# Altium schematic sheet DPad.SchDoc(DPad)
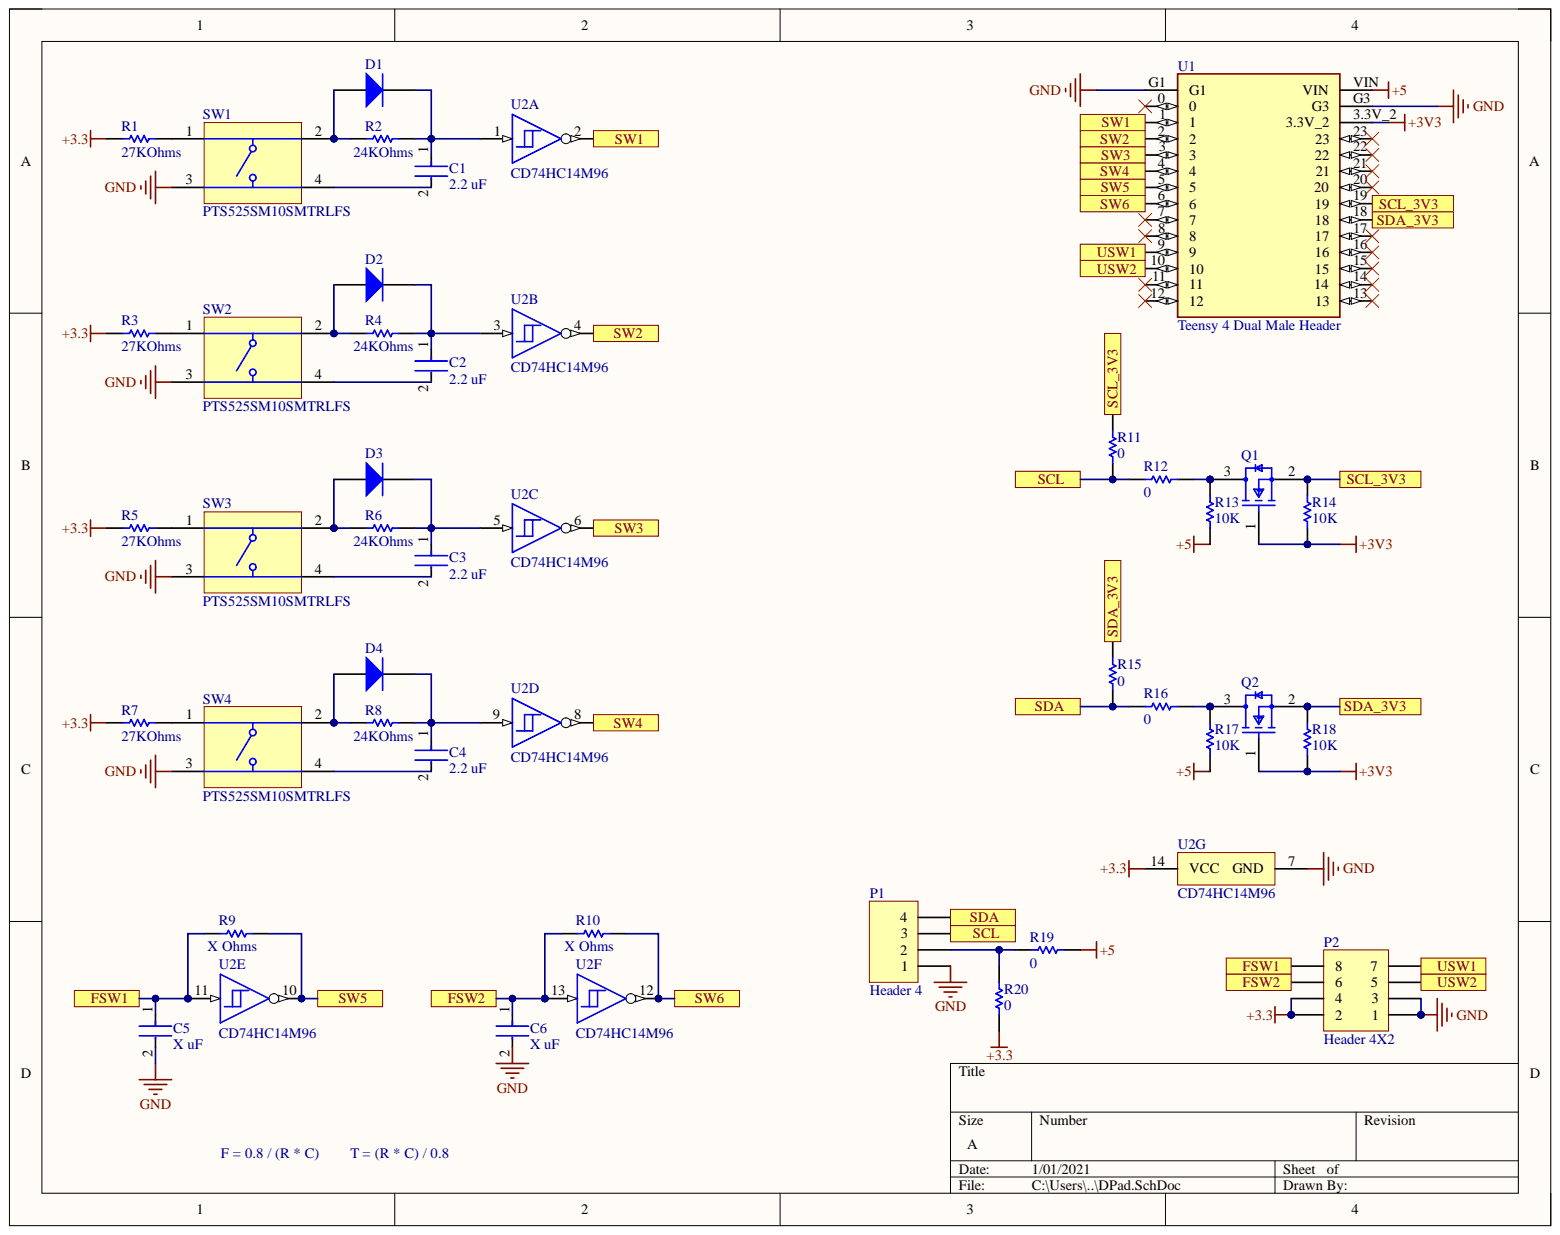
[diagram p0: DPad.SchDoc]

## Parts
C1  value 2.2 uF  Capacitance: 1 uF  [FP-CC0805-0_85-IPC_B]  (hidden comment: CC0805KKX7R6BB105)
C2  value 2.2 uF  Capacitance: 1 uF  [FP-CC0805-0_85-IPC_B]  (hidden comment: CC0805KKX7R6BB105)
C3  value 2.2 uF  Capacitance: 1 uF  [FP-CC0805-0_85-IPC_B]  (hidden comment: CC0805KKX7R6BB105)
C4  value 2.2 uF  Capacitance: 1 uF  [FP-CC0805-0_85-IPC_B]  (hidden comment: CC0805KKX7R6BB105)
C5  value X uF  Capacitance: 1 uF  [FP-CC0805-0_85-IPC_B]  (hidden comment: CC0805KKX7R6BB105)
C6  value X uF  Capacitance: 1 uF  [FP-CC0805-0_85-IPC_B]  (hidden comment: CC0805KKX7R6BB105)
D1  1N4148WS-E3-08  [VISH-SOD-323-2_V]  (value not drawn)
D2  1N4148WS-E3-08  [VISH-SOD-323-2_V]  (value not drawn)
D3  1N4148WS-E3-08  [VISH-SOD-323-2_V]  (value not drawn)
D4  1N4148WS-E3-08  [VISH-SOD-323-2_V]  (value not drawn)
P1  Header 4  [HDR1X4]
P2  Header 4X2  [HDR2X4]
Q1  BSS138  [FP-318-08-IPC_B]  (value not drawn)
Q2  BSS138  [FP-318-08-IPC_B]  (value not drawn)
R1  value 27KOhms  [RESC2013X50X30LL20T20]  (hidden comment: CRCW08050000Z0EA)
R2  value 24KOhms  [RESC2013X50X30LL20T20]  (hidden comment: CRCW08050000Z0EA)
R3  value 27KOhms  [RESC2013X50X30LL20T20]  (hidden comment: CRCW08050000Z0EA)
R4  value 24KOhms  [RESC2013X50X30LL20T20]  (hidden comment: CRCW08050000Z0EA)
R5  value 27KOhms  [RESC2013X50X30LL20T20]  (hidden comment: CRCW08050000Z0EA)
R6  value 24KOhms  [RESC2013X50X30LL20T20]  (hidden comment: CRCW08050000Z0EA)
R7  value 27KOhms  [RESC2013X50X30LL20T20]  (hidden comment: CRCW08050000Z0EA)
R8  value 24KOhms  [RESC2013X50X30LL20T20]  (hidden comment: CRCW08050000Z0EA)
R9  value X Ohms  [RESC2013X50X30LL20T20]  (hidden comment: CRCW08050000Z0EA)
R10  value X Ohms  [RESC2013X50X30LL20T20]  (hidden comment: CRCW08050000Z0EA)
R11  0  [RESC2013X50X30LL20T20]
R12  0  [RESC2013X50X30LL20T20]
R13  10K  [RESC2013X50X30LL20T20]
R14  10K  [RESC2013X50X30LL20T20]
R15  0  [RESC2013X50X30LL20T20]
R16  0  [RESC2013X50X30LL20T20]
R17  10K  [RESC2013X50X30LL20T20]
R18  10K  [RESC2013X50X30LL20T20]
R19  0  [RESC2013X50X30LL20T20]
R20  0  [RESC2013X50X30LL20T20]
SW1  PTS525SM10SMTRLFS  [CKCP-PTS525SM10SMTR-4_V]
SW2  PTS525SM10SMTRLFS  [CKCP-PTS525SM10SMTR-4_V]
SW3  PTS525SM10SMTRLFS  [CKCP-PTS525SM10SMTR-4_V]
SW4  PTS525SM10SMTRLFS  [CKCP-PTS525SM10SMTR-4_V]
U1  Teensy 4 Dual Male Header  [Teensy 4 Dual Male Header-Footprint-2]
U2  CD74HC14M96  [D0014A_M]  (parts A,B,C,D,E,F,G)

## Ports
FSW1, FSW2, SCL, SCL_3V3, SDA, SDA_3V3, SW1, SW2, SW3, SW4, SW5, SW6, USW1, USW2

## Nets
+3.3: P2.2 P2.4 R1.2 R3.2 R5.2 R7.2 R20.2 U2.14(VCC)
+3V3: Q1.1 Q2.1 R14.1 R18.1 U1.3.3V_2
+5: R13.1 R17.1 R19.1 U1.VIN
GND: C5.2 C6.2 P1.1 P2.1 P2.3 SW1.3 SW2.3 SW3.3 SW4.3 U1.G1 U1.G3 U2.7(GND)
FSW1: C5.1 P2.8 R9.1 U2.11 ; port FSW1
FSW2: C6.1 P2.6 R10.1 U2.13 ; port FSW2
SCL: P1.3 R11.1 R12.2 ; port SCL
SCL_3V3: Q1.2 R11.2 R14.2 U1.19 ; port SCL_3V3
SDA: P1.4 R15.2 R16.2 ; port SDA
SDA_3V3: Q2.2 R15.1 R18.2 U1.18 ; port SDA_3V3
SW1: U1.1 U2.2 ; port SW1
SW2: U1.2 U2.4 ; port SW2
SW3: U1.3 U2.6 ; port SW3
SW4: U1.4 U2.8 ; port SW4
SW5: R9.2 U1.5 U2.10 ; port SW5
SW6: R10.2 U1.6 U2.12 ; port SW6
USW1: P2.7 U1.9 ; port USW1
USW2: P2.5 U1.10 ; port USW2
NetC1_1: C1.1 D1.2 R2.2 U2.1
NetC1_2: C1.2 SW1.4
NetC2_1: C2.1 D2.2 R4.2 U2.3
NetC2_2: C2.2 SW2.4
NetC3_1: C3.1 D3.2 R6.2 U2.5
NetC3_2: C3.2 SW3.4
NetC4_1: C4.1 D4.2 R8.2 U2.9
NetC4_2: C4.2 SW4.4
NetD1_1: D1.1 R2.1 SW1.2
NetD2_1: D2.1 R4.1 SW2.2
NetD3_1: D3.1 R6.1 SW3.2
NetD4_1: D4.1 R8.1 SW4.2
NetP1_2: P1.2 R19.2 R20.1
NetQ1_3: Q1.3 R12.1 R13.2
NetQ2_3: Q2.3 R16.1 R17.2
NetR1_1: R1.1 SW1.1
NetR3_1: R3.1 SW2.1
NetR5_1: R5.1 SW3.1
NetR7_1: R7.1 SW4.1

Not connected: U1 0 7 8 11 12 13 14 15 16 17 20 21 22 23

## Library data of the parts
C1-C6 = CMP-03422-000182-1: CAP CER 1UF 10V X7R 0805; Manufacturer: Yageo; Material: Ceramic; Tolerance: 0.1; Automotive: No; Category: Cap; Component Kind: Standard; ComponentLink2Description: Datasheet; ComponentLink2URL: http://www.yageo.com/documents/recent/UPY-GPHC_Y5V_6.3V-to-50V_8.pdf; Device Class L1: Passive Components; Device Class L2: Capacitors; Device Class L3: Ceramic Capacitors; Digikey Description: CAP CER 1UF 10V X7R 0805; Footprint Url: http://www.yageo.com/exep/pages/download/literatures/UPY-C_GEN_24.pdf; Height: 0.95mm; Ipc Land Pattern Name: CAPC200125X85; Lead Free: Yes; Library Name: Altium Content Vault; Manufacturer Part Number: CC0805KKX7R6BB105; Mouser Description: Multilayer Ceramic Capacitors MLCC - SMD/SMT 1.0uF 10V X7R 10%; Octopart: https://octopart.com/cc0805kkx7r6bb105-yageo-42522859; Package: CC0805-0.85; RoHS: TRUE; Temperature: -30°C to +85°C; Temperature Characteristic: X7R; Temperature Range High: +85°C; Temperature Range Low: -30°C; Voltage Rating: 10 V
D1-D4 = CMP-2000-05517-2: Small Signal Fast Switching Diode, 1.2 V, 350 mA, -55 to 150 degC, 2-Pin SOD-323, RoHS, Tape and Reel 1N4148WS-E3-08; Manufacturer: Vishay; Brand: VishaySemiconductors; Forward Continuous Current: 150mA; Forward Voltage Drop: 1.2V; Max Surge Current: 350mA; Maximum Diode Capacitance: 4pF; Maximum Power Dissipation: 200mW; Maximum Reverse Leakage Current: 100uA; Package Version: Rev. 5, 09/2009; Peak Reverse Voltage: 100V; Recovery Time: 4ns; Unit Weight: 0.000152oz; Component Kind: Standard; Datasheet URL: http://www.vishay.com/docs/85751/1n4148ws.pdf; Datasheet Version: Rev. 2.0, 05/2013; Factory Pack Quantity: 3000; Library Name: Altium Content Vault; Manufacturer URL: http://www.vishay.com/; Maximum Operating Temperature: +150C; Minimum Operating Temperature: -55C; Mounting Style: SMD/SMT; Mounting Technology: SurfaceMount; Operating Temperature Range: -55Cto+150C; Package / Case: SOD-323; Package Description: 2-Pin Surface Mount Device, Body 1.775 x 1.3 mm, Height 1.15 mm; Package Reference: SOD-323-2; Package URL: http://www.st.com/st-web-ui/static/active/en/resource/technical/document/datasheet/CD00233088.pdf; Packaging: Reel; Product: SwitchingDiodes; Product Category: Diodes-GeneralPurpose,Power,Switching; RoHS: Details; Series: 1N4148WS
P1 = CMP-049-0097-1: Header, 4-Pin; Component Kind: Standard; Component Type: Standard; Design Item ID: Header 4; LatestRevisionDate: 17-Jul-2002; LatestRevisionNote: Re-released for DXP Platform.; Pin Count: 4; Signal Integrity: Connector; Source: Miscellaneous Connectors.SchLib; Library Name: Gannon University; Publisher: Altium Limited
P2 = CMP-049-0099-1: Header, 4-Pin, Dual row; Component Kind: Standard; Component Type: Standard; Design Item ID: Header 4X2; LatestRevisionDate: 17-Jul-2002; LatestRevisionNote: Re-released for DXP Platform.; Pin Count: 8; Signal Integrity: Connector; Source: Miscellaneous Connectors.SchLib; Library Name: Gannon University; Publisher: Altium Limited
Q1 Q2 = CMP-07173-000101-1: MOSFET N-CH 50V 220MA SOT-23; Drain to Source Resistance: 3.5R; Element Configuration: Single; Fall Time: 9ns; Gate to Source Voltage (Vgs): 20V; Input Capacitance: 27pF; Max Power Dissipation: 360mW; Nominal Vgs: 1.3V; Number of Elements: 1; On-State Resistance: 6R; Turn-Off Delay Time: 20ns; Turn-On Delay Time: 2.5ns; Case/Package: SOT-23; Component Kind: Standard; Contact Plating: Tin; Continuous Drain Current (ID): 220mA; Current Rating: 220mA; Drain to Source Breakdown Voltage: 50V; Drain to Source Voltage (Vdss): 50V; Dual Supply Voltage: 50V; Height: 0.93mm; Lead Free: Lead Free; Length: 2.92mm; Library Name: Altium Content Vault; Max Operating Temperature: 150°C; Min Operating Temperature: -55°C; Mount: Surface Mount; Number of Pins: 3; Package Quantity: 1; Packaging: Tape and Reel; Power Dissipation: 360mW; Radiation Hardening: No; Rds On Max: 3.5R; REACH SVHC: No SVHC; Rise Time: 9ns; Termination: SMD/SMT; Threshold Voltage: 1.3V; Voltage Rating (DC): 50V; Weight: 0.03g; Width: 1.3mm
R1-R10 = CMP-2001-04896-1: Manufacturer: Vishay Dale; Component Kind: Standard; Library Name: Altium Content Vault; Part Number: CRCW08050000Z0EA
R11-R20 = CMP-2001-03735-1: Manufacturer: Vishay Dale; Part Number: CRCW0805270RFKEA; Component Kind: Standard; Library Name: Altium Content Vault
SW1-SW4 = CMP-2000-05088-1: Microminiature Tact Switch, SPST-NO, 50 mA, 12 VDC, -40 to 60 degC, 4-Pin SMD, RoHS, Tape and Reel; Manufacturer: C&K Components; Actuator Length: 0.3mm; Actuator Style: RoundButton; Base Material: Nylon6/6,Glass/Polyester; Body Orientation: Straight; Contact Rating @ Voltage: 0.05@12VDCA; Dielectric Strength: 250VAC; Ground Terminal: No; Illumination Type: N/R; Maximum Contact Resistance: 100mOhm; Maximum DC Voltage Rating: 12V; Mechanical Life: 100000Cycles; Minimum Insulation Resistance: 100000MOhm; Operating Force: 1.57N; Pole Throw Configuration: SPST; Switch Configuration: N.O.; Actuator Color: N/A; Component Kind: Standard; Contact Material: PhosphorBronze; Contact Plating: SilverClad; Datasheet URL: https://datasheet.ciiva.com/18145/getdatasheetpartid-226915-18145102.pdf; Datasheet Version: Apr-09; Dimension: 5.25x6.4x1.5mm; ECCN: EAR99; Electrical Life: N/A; Family: PTS525; Housing Material: N/A; HTS: 8536501199; Library Name: Altium Content Vault; Manufacturer URL: http://www.ckswitches.com/; Maximum AC Voltage Rating: N/A; Mounting: SurfaceMount,GullWing; Operating Temperature: -40to60C; Packaging: TapeandReel; Part No: PTS525SM10SMTRLFS; Product Weight: N/A; RoHS: Yes; Seal Description: N/A; Seal Location: N/A; Supplier: C&KComponents; Supplier Standard Pack: 3,000; Type: N/A
U1 = CMP-016-0003-2: Header interface for dual female breakout; Component Kind: Standard; Library Name: Gannon University
U2 = CMP-1615-00211-3: High Speed CMOS Logic Hex Schmitt-Triggered Inverters, D0014A, LARGE T&R; Manufacturer: Texas Instruments; Bits(#): 6; F @ Nom Voltage(Max)(Mhz): 28; ICC @ Nom Voltage(Max)(mA): 0.02; Output Drive (IOL/IOH)(Max)(mA): -5.2/5.2; Rating: Catalog; Schmitt Trigger: Yes; Technology Family: HC; tpd @ Nom Voltage(Max)(ns): 29; VCC(Min)(V): 2; Code_JEDEC: MS-012; Component Kind: Standard; DatasheetVersion: SCHS129F; Generic Part Number: CD74HC14; Input Type: CMOS; Library Name: Altium Content Vault; Operating Temperature Range(C): -55 to 125; Output Type: CMOS; PackageReference: D0014A; VCC(Max)(V): 6; Voltage(Nom)(V): 6
